# Altium schematic sheet Sheet2.SchDoc
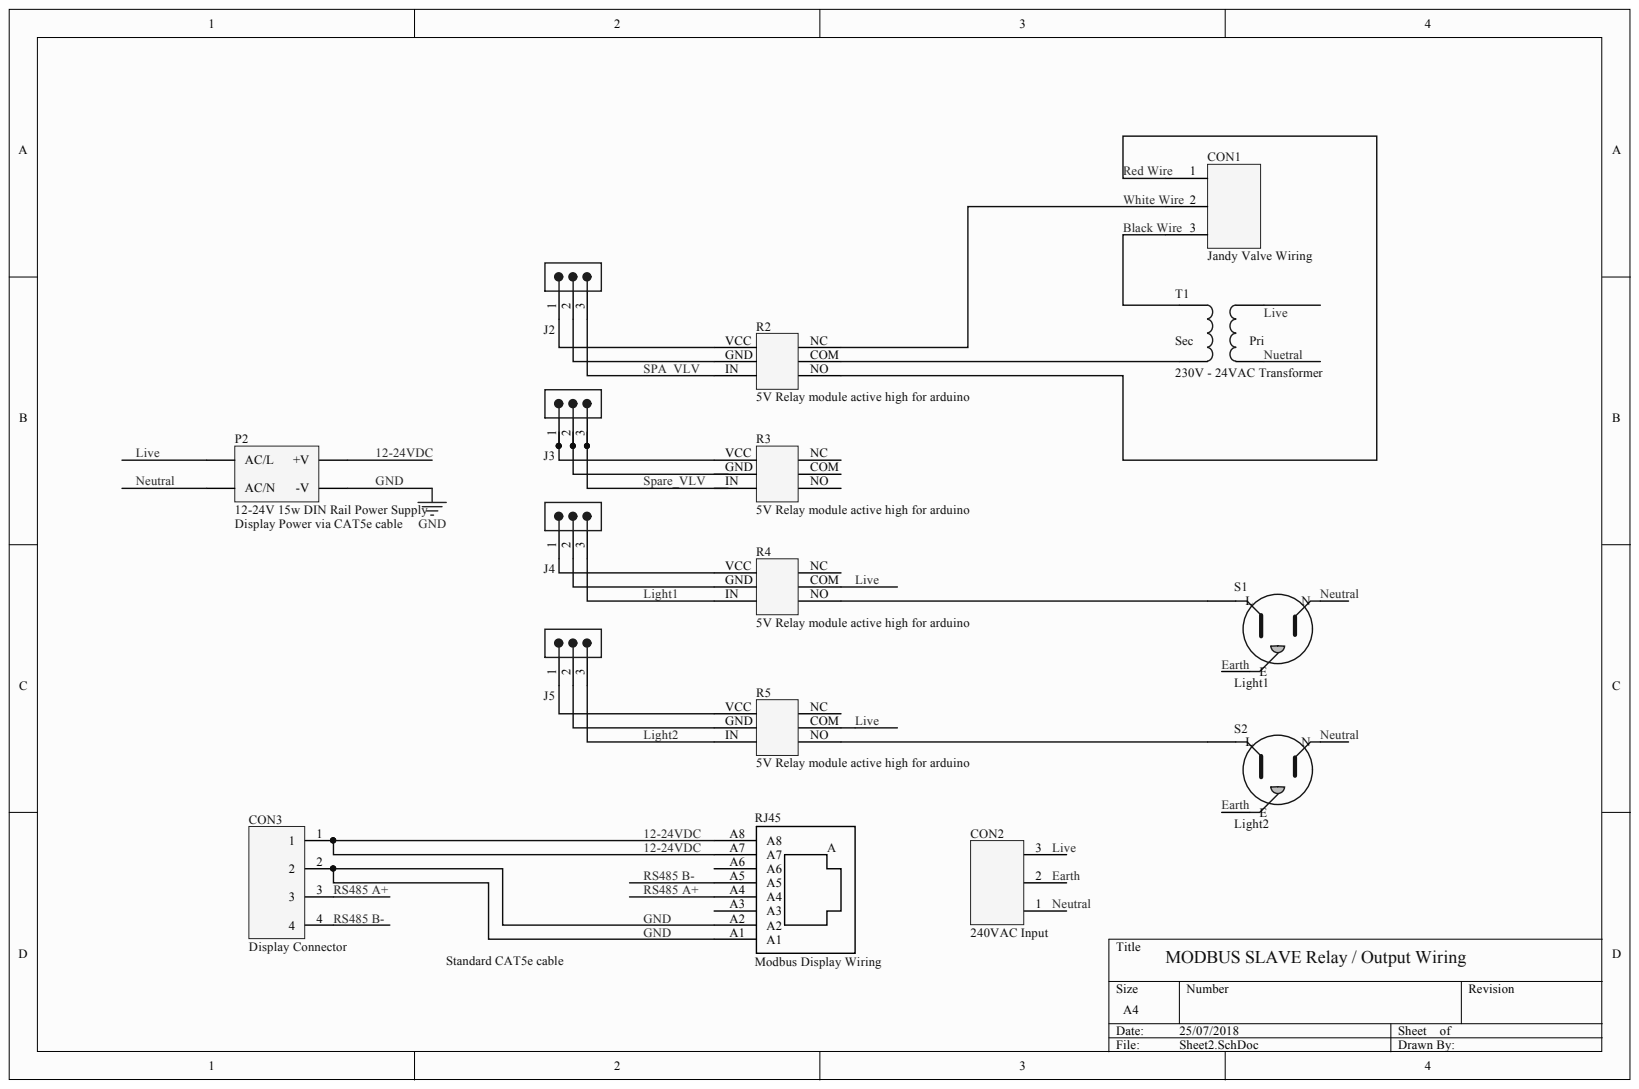
[diagram p0: Sheet2.SchDoc]

## Parts
CON1  Jandy Valve Wiring
CON2  240VAC Input
CON3  Display Connector  [Phoenix-Plug-4]
J2  Harwin M20-9990345  [PCB-0acd9ij3o7hs0jkl1v86-1]  (value not drawn)
J3  Harwin M20-9990345  [PCB-0acd9ij3o7hs0jkl1v86-1]  (value not drawn)
J4  Harwin M20-9990345  [PCB-0acd9ij3o7hs0jkl1v86-1]  (value not drawn)
J5  Harwin M20-9990345  [PCB-0acd9ij3o7hs0jkl1v86-1]  (value not drawn)
P2  12-24V 15w DIN Rail Power Supply  [PCB-8se8ygxm91lj2okei718-1]
R2  5V Relay module active high for arduino
R3  5V Relay module active high for arduino
R4  5V Relay module active high for arduino
R5  5V Relay module active high for arduino
RJ45  Modbus Display Wiring  [PCB-eigj3alnl7k3lw38oz6g-1]
S1  Light1  [PCB-ehmxs8x4vdyh94h2z38c-1]
S2  Light2  [PCB-ehmxs8x4vdyh94h2z38c-1]
T1  230V - 24VAC Transformer  [PCB-3u9k73d4n9v9bbf22txy-1]

## Nets
12-24VDC: CON3.1 P2.+V RJ45.A7 RJ45.A8
BLACK WIRE: CON1.3 T1.4
EARTH: S1.3 S2.3
GND: CON3.2 P2.-V RJ45.A1 RJ45.A2
LIGHT1: J4.3
LIGHT2: J5.3
LIVE: P2.AC/L R4.COM R5.COM
NEUTRAL: P2.AC/N
NUETRAL: T1.1
RED WIRE: CON1.1 R2.NO
RS485 A+: CON3.3 RJ45.A4
RS485 B-: CON3.4 RJ45.A5
SPA_VLV: J2.3
SPARE_VLV: J3.3
WHITE WIRE: CON1.2 R2.NC
NetJ2_1: J2.1
NetJ3_1: J3.1
NetJ3_2: J3.2
NetJ4_1: J4.1
NetJ4_2: J4.2
NetR2_COM: R2.COM T1.3
NetR4_NO: R4.NO S1.1
NetR5_NO: R5.NO S2.1

Not connected: CON2 1 2 3; J2 2; J5 1 2; R2 GND IN VCC; R3 COM GND IN NC NO VCC; R4 GND IN NC VCC; R5 GND IN NC VCC; RJ45 A3 A6; S1 2; S2 2; T1 2

## Library data of the parts
CON1 CON2 = CMP-c895019fee751752-1: Socket enclosure - cable MSTB Phoenix Contact 1757022 Contact spacing: 5.08 mm 1 pc(s); Current Rating: 12.0 A; Gender: Male; Lead-Free Status: Lead Free; Mounting Angle: 180 °; Number of Contacts: 3; Pin Pitch: 5.08 mm; Color: Green; Component Kind: Standard; Contact Plating: Tin; Flammability Rating: UL 94 V-0; Housing Color: Green; Housing Material: Nylon; Insulation Material: Nylon; Library Name: CommunityVault; Manufacturer Part Number: 1757022; Mounting Style: Screw; Number of Positions: 3; Number of Rows: 1; OctopartID: c895019fee751752; Octopart URL: http://octopart.com/1757022-phoenix+contact-902; RoHS: Compliant; Size-Height: 15.0 mm; Size-Length: 5.08 mm; Size-Width: 18.2 mm
CON3 = CMP-39e1f0ebdc0ee626-5: Connector Terminal Blocks 4 POS 5mm Solder Right Angle/Straight Thru-Hole 12A; Component Kind: Standard; Library Name: CommunityVault
J2-J5 = CMP-683aa16207d4b7fd-11: 2.54mm (0.1") Pitch SIL Vertical PC Tail Pin Header, 6.1mm mating pin height, gold (hi-temp mould), 3 contacts; Contact Resistance: 15.0 mΩ; Current Rating: 3.00 A; Gender: Male; Number of Contacts: 3; Number of Mating Cycles: 300; Voltage Rating (AC): 750 V; Component Kind: Standard; Contact Material: Phosphor Bronze; Library Name: CommunityVault; Manufacturer Part Number: M20-9990345; Mounting Style: Through Hole, Vertical; Octopart URL: https://octopart.com/m20-9990345-harwin-5393032; Pin Pitch: 2.54 mm; REACH SVHC Compliance: No SVHC; RoHS: Compliant
P2 = CMP-9af15223914a4536-2: Mean Well Open Frame Power Supply; Component Kind: Standard; Library Name: CommunityVault
R2-R5 = CMP-ffz422utqx0ddg3mesy8-1: Component Kind: Standard; CustomComponentID: ffz422utqx0ddg3mesy8; Description: 5V Relay module active high for arduino; Library Name: CommunityVault
RJ45 = CMP-5dc295d75c218205-1: Modular jack vertical [Würth Elektronik] 615008138221 Modular jack vertical; Gender: Male; Number of Contacts: 8; Component Kind: Standard; Contact Material: Copper; Contact Plating: Gold; Library Name: CommunityVault; Manufacturer Part Number: 615008138221; Mounting Style: Through Hole, Vertical; OctopartID: 5dc295d75c218205; Octopart URL: http://octopart.com/615008138221-w%C3%BCrth+elektronik-18818181; Packaging: Tray; RoHS: Compliant
S1 S2 = CMP-209febf88cb0ff58-7: Conn Power RCP 2Power/1Ground POS 12.7mm Solder ST Thru-Hole 3 Terminal 1 Port; Manufacturer Part Number: 3-213598-2; Current Rating: 15.0 A; ELV Compliant: Compliant; Gender: Female; Lead-Free Status: Lead Free; Color: Black; Component Kind: Standard; Contact Material: Brass; Contact Plating: Tin; Housing Material: Nylon; Library Name: CommunityVault; Lifecycle Status: Active; Mounting Style: Solder; OctopartID: 209febf88cb0ff58; Octopart URL: http://octopart.com/3-213598-2-te+connectivity+%2F+amp-40733001; Packaging: Box; REACH SVHC Compliance: No SVHC; RoHS: Compliant
T1 = CMP-d0db1967e7e50f1c-4: PCB transformer [Block] VB 0.35/1/12 PCB transformer; Component Kind: Standard; Library Name: CommunityVault
